# Altium schematic sheet POWER.SchDoc(POWER)
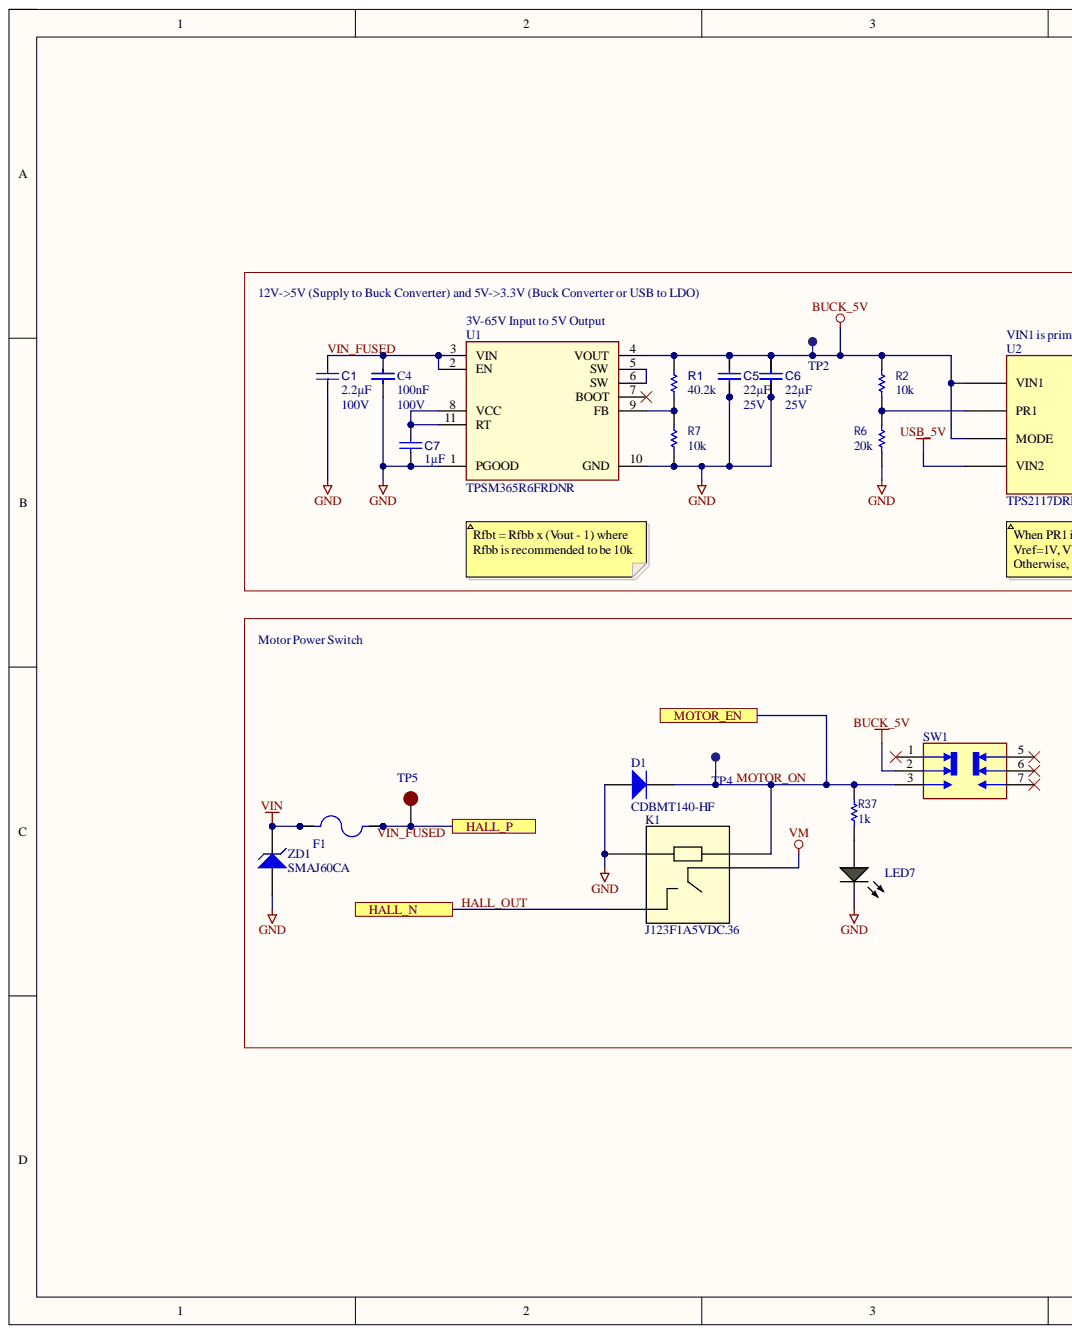
[diagram p0: POWER.SchDoc, left]
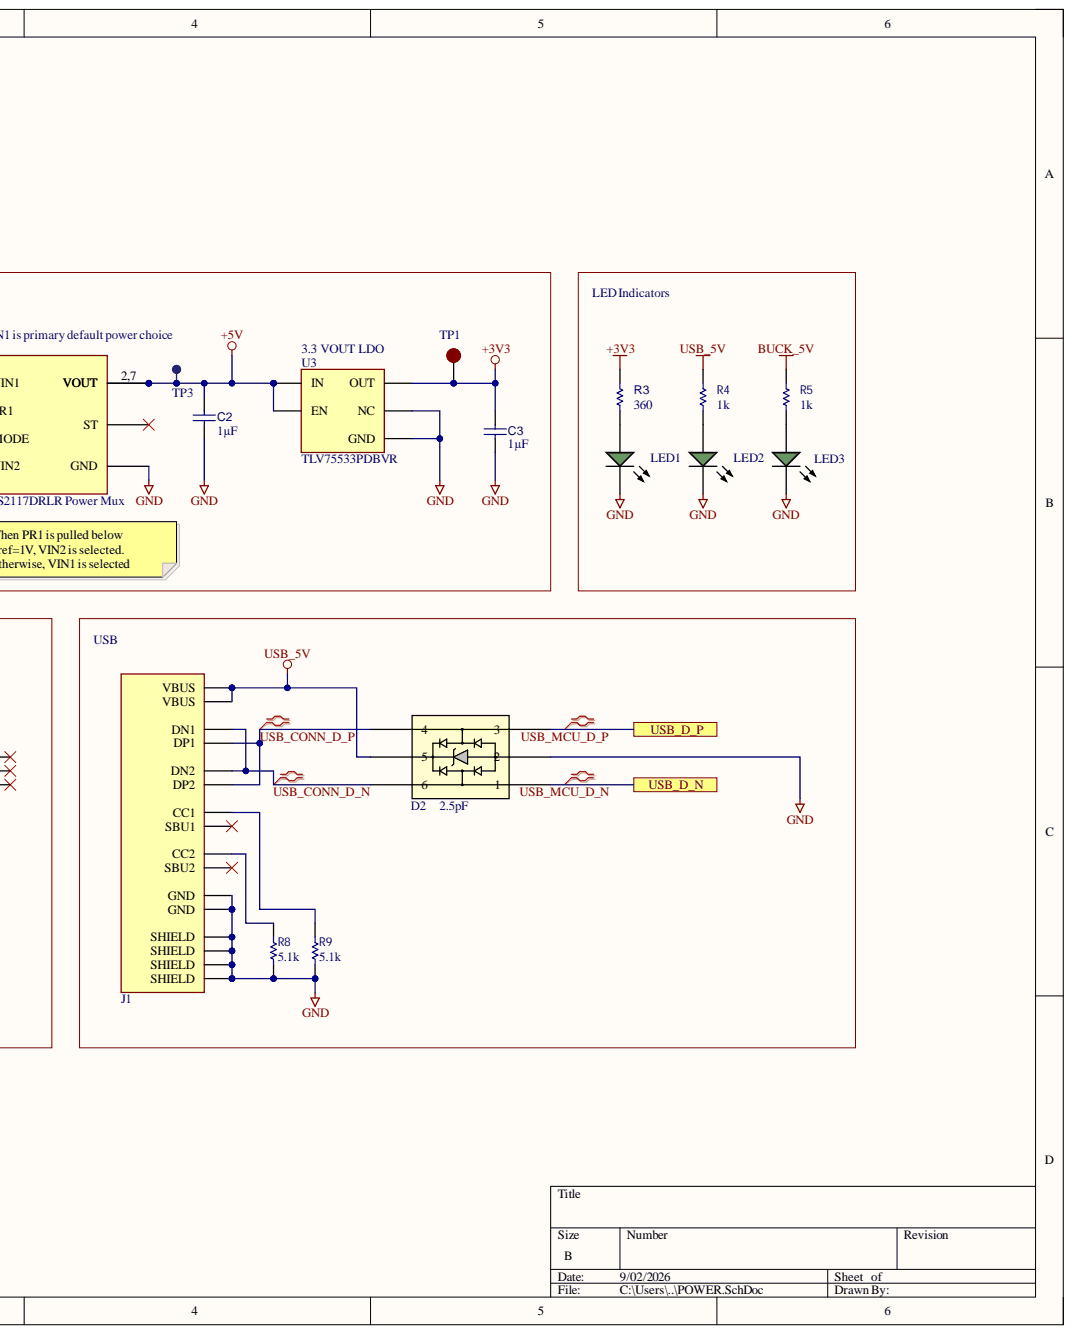
[diagram p1: POWER.SchDoc, right]

## Parts
C1  2.2µF  Voltage Rating: 100V  [CAPC3216X200N]
C2  1µF  [0603_Capacitor]
C3  1µF  [0603_Capacitor]
C4  100nF  Voltage Rating: 100V  [0603_Capacitor]
C5  22µF  Voltage Rating: 25V  [CAPC3225X28N]
C6  22µF  Voltage Rating: 25V  [CAPC3225X28N]
C7  1µF  [0603_Capacitor]
D1  CDBMT140-HF  [FP-SOD123F_Type_B-MFG]
D2  2.5pF  [SOT23-6L_STM]
F1  3557-2  [FUSE_3557-2]  (value not drawn)
J1  UJ20-C-H-G-SMT-1-P16-TR  [SAMESKY_UJ20-C-H-G-SMT-1-P16-TR]  (value not drawn)
K1  J123F1A5VDC.36  [RELAY4_J123F-1A_CRS]
LED1  LTST-C190GKT  [LTST-C190GKT_V]  (value not drawn)
LED2  LTST-C190GKT  [LTST-C190GKT_V]  (value not drawn)
LED3  LTST-C190GKT  [LTST-C190GKT_V]  (value not drawn)
LED7  LTST-C190GKT  [LTST-C190GKT_V]  (value not drawn)
R1  40.2k  [R-0402]
R2  value 10k  [R-0402]  (hidden comment: Resistor 10k)
R3  360  [R-0402]
R4  value 1k  [R-0402]  (hidden comment: Resistor 1k)
R5  value 1k  [R-0402]  (hidden comment: Resistor 1k)
R6  value 20k  [R-0402]  (hidden comment: Resistor 20k)
R7  value 10k  [R-0402]  (hidden comment: Resistor 10k)
R8  value 5.1k  [R-0402]  (hidden comment: Resistor 5.1k)
R9  value 5.1k  [R-0402]  (hidden comment: Resistor 5.1k)
R37  value 1k  [R-0402]  (hidden comment: Resistor 1k)
SW1  JS202011JCQN  [SW_JS202011JCQN]  (value not drawn)
TP1  5000 TP  [FP-5000-MFG]  (value not drawn)
TP2  Test Pad  [Test_Pad]  (value not drawn)  (no BOM)
TP3  Test Pad  [Test_Pad]  (value not drawn)  (no BOM)
TP4  Test Pad  [Test_Pad]  (value not drawn)  (no BOM)
TP5  5000 TP  [FP-5000-MFG]  (value not drawn)
U1  TPSM365R6FRDNR  [RDN0011A-MFG_FIXED]
U2  TPS2117DRLR Power Mux  Manufacturer PN: TPS2117DRLR  Type: Power Mux  [SOTFL50P160X60-8N]
U3  TLV75533PDBVR  Type: LDO  [FP-DBV0005A-IPC_C]
ZD1  SMAJ60CA  [SMASeries_LTF]

## Ports
HALL_N, HALL_P, MOTOR_EN, USB_D_N, USB_D_P

## Nets
+3V3: C3.1 R3.2 TP1.1 U3.5(OUT)
+5V: C2.1 TP3.TP? U2.2(VOUT) U2.7 U3.1(IN) U3.3(EN)
BUCK_5V: C5.2 C6.2 R1.2 R2.2 R5.2 SW1.2 TP2.TP? U1.4(VOUT) U2.3(VIN1) U2.5(MODE)
GND: C1.1 C2.2 C3.2 C4.2 C5.1 C6.1 C7.1 D1.1 D2.2 J1.A1_B12(GND) J1.B1_A12(GND) J1.SH1(SHIELD) J1.SH2(SHIELD) J1.SH3(SHIELD) J1.SH4(SHIELD) K1.2 LED1.2 LED2.2 LED3.2 LED7.2 R6.1 R7.1 R8.1 R9.1 U1.1(PGOOD) U1.10(GND) U2.1(GND) U3.2(GND) U3.4(NC) ZD1.1
USB_5V: D2.5 J1.A4_B9(VBUS) J1.B4_A9(VBUS) R4.2 U2.6(VIN2)
VIN: F1.1_1 F1.1_2 ZD1.2
VM: K1.1
HALL_OUT: K1.3 ; port HALL_N
MOTOR_ON: D1.2 K1.4 R37.2 SW1.3 TP4.TP? ; port MOTOR_EN
USB_CONN_D_N: D2.6 J1.A7(DN1) J1.B7(DN2)
USB_CONN_D_P: D2.4 J1.A6(DP1) J1.B6(DP2)
USB_MCU_D_N: D2.1 ; port USB_D_N
USB_MCU_D_P: D2.3 ; port USB_D_P
VIN_FUSED: C1.2 C4.1 F1.2_1 F1.2_2 TP5.1 U1.2(EN) U1.3(VIN) ; port HALL_P
NetC7_2: C7.2 U1.8(VCC) U1.11(RT)
NetJ1_A5: J1.A5(CC1) R9.2
NetJ1_B5: J1.B5(CC2) R8.2
NetLED1_1: LED1.1 R3.1
NetLED2_1: LED2.1 R4.1
NetLED3_1: LED3.1 R5.1
NetLED7_1: LED7.1 R37.1
NetR1_1: R1.1 R7.2 U1.9(FB)
NetR2_1: R2.1 R6.2 U2.4(PR1)
NetU1_5: U1.5(SW) U1.6(SW)

Not connected: J1 A8(SBU1) B8(SBU2); SW1 1 5 6 7; U1 7(BOOT); U2 12(ST)

## Library data of the parts
C1 = CMP-001-00240-1: Cap Ceramic 2.2uF 100V X7R 10% Pad SMD 1206 125C T/R Automotive AEC-Q200; Manufacturer: KYOCERA AVX; Manufacturer PN: 12061C225K4T2A; Type: Capacitor X7R; Component Kind: Standard; ComponentLink1Description: Datasheet; ComponentLink1URL: https://datasheets.kyocera-avx.com/AutoMLCC.pdf; Library Name: BLDC_Symbols.SchLib; Package: 1206; Supplier 1: Digikey; Supplier Part Number 1: 478-KAM31GR72A225KUCT-ND; Tolerance: 10%; Value: 2.2µF
C2 C3 C7 = CMP-001-00209-4: 1 µF ±10% 25V Ceramic Capacitor X7R 0603 (1608 Metric); Manufacturer: Samsung Electro-Mechanics; Manufacturer PN: CL10B105KA8NNNC; Component Kind: Standard; ComponentLink1Description: Datasheet; ComponentLink1URL: https://mm.digikey.com/Volume0/opasdata/d220001/medias/docus/3644/CL10B105KA8NNNC_spec.pdf; Library Name: BLDC_Symbols.SchLib; Package: 0603; Supplier 1: Digikey; Supplier Part Number 1: 1276-1184-1-ND; Tolerance: 10%; Type: Capacitor X7R; Value: 1µF; Voltage Rating: 25V
C4 = CAP, SMD, 0.1uF 0603 100V X7R: 0.1 µF ±10% 100V Ceramic Capacitor X7R 0603 (1608 Metric); Manufacturer: Walsin Technology Corporation; Manufacturer PN: 0603B104K101CT; Component Kind: Standard; ComponentLink1Description: Datasheet; ComponentLink1URL: https://www.mouser.com/datasheet/3/508/1/KEM_C1002_X7R_SMD.pdf; Library Name: BLDC_Symbols.SCHLIB; Package: 0603; Supplier 1: Digikey; Supplier Part Number 1: 1292-1392-1-ND; Tolerance: 10%; Type: Capacitor X7R; Value: 100nF
C5 C6 = CMP-001-00218-1: 25V 22uF X7R 卤20% 1210 Multilayer Ceramic Capacitors MLCC - SMD/SMT ROHS; Manufacturer: TDK; Manufacturer PN: C3225X7R1E226M250AB; Tolerance: 20%; Type: Capacitor X7R; Component Kind: Standard; ComponentLink1Description: Datasheet; ComponentLink1URL: https://product.tdk.com/system/files/dam/doc/product/capacitor/ceramic/mlcc/catalog/mlcc_commercial_general_en.pdf; Library Name: BLDC_Symbols.SchLib; Package: 1210; Supplier 1: Digikey; Supplier Part Number 1: 445-C3225X7R1E226M250ABCT-ND; Value: 22µF
D1 = CMP-00027-2: Rectifier Diode, 1 Phase, 1 Element, 1A, 40V V(RRM), Silicon; Manufacturer: Comchip; Type: Schottky Diode; Component Kind: Standard; ComponentLink1Description: Datasheet; ComponentLink1URL: https://www.comchiptech.com/admin/files/product/CDBMT140-HF%20Thru%20CDBMT1200-HF%20RevC.pdf; Current: 1A; Library Name: BLDC_Symbols.SchLib; Manufacturer PN: CDBMT140-HF; Package: SOD123H; Supplier 1: Digikey; Supplier Part Number 1: 641-1443-1-ND; Value: CDBMT140-HF; Voltage Rating: 40V
D2 = CMP-004-00065-3: 5A 17V 6V Unidirectional 5.25V SOT-23-6 ESD and Surge Protection (TVS/ESD) ROHS; Manufacturer: STMicroelectronics; Manufacturer PN: USBLC6-2SC6; Type: TVS Diode Array; Component Kind: Standard; ComponentLink1Description: Datasheet; ComponentLink1URL: https://www.st.com/resource/en/datasheet/cd00050750.pdf; Current: 5A; Library Name: BLDC_Symbols.SchLib; Package: SOT-23; Supplier 1: Mouser; Value: 2.5pF; Voltage Rating: 17V
F1 = CMP-005-00018-1: THM 2 in 1 Auto Blade Holder; Manufacturer: Keystone Electronics; Current: 30A; Type: Fuse Holder; Voltage Rating: 500V; Component Kind: Standard; ComponentLink1Description: Datasheet; ComponentLink1URL: https://www.keyelco.com/userAssets/file/K75p47.pdf; Library Name: BLDC_Symbols.SchLib; Manufacturer PN: 3557-2; Package: TH; Supplier 1: Digikey; Supplier Part Number 1: 36-3557-2-ND; Value: 3557-2
J1 = CMP-002-00331-2: USB-C (USB TYPE-C) USB 2.0 Receptacle Connector 24 (16+8 Dummy) Position Surface Mount, Right Angle; Through Hole; Manufacturer: Same Sky (Formerly CUI Devices); Voltage Rating: 48V; Component Kind: Standard; ComponentLink1Description: Datasheet; ComponentLink1URL: https://www.sameskydevices.com/product/resource/uj20-c-h-g-smt-1-p16-tr.pdf; Library Name: BLDC_Symbols.SchLib; Manufacturer PN: UJ20-C-H-G-SMT-1-P16-TR; Package: SMD; Supplier 1: Digikey; Supplier Part Number 1: 2223-UJ20-C-H-G-SMT-1-P16-TRCT-ND; Type: USB-C Connector (Right Angle); Value: UJ20-C-H-G-SMT-1-P16-TR
K1 = CMP-008-00026-1: Description; Manufacturer: CIT Relay and Switch; Current: 20A; Power: 2200W; Voltage Rating: 110V; Component Kind: Standard; ComponentLink1Description: Datasheet; ComponentLink1URL: https://www.citrelay.com/wp-content/uploads/2025/05/Relay-J123F.pdf; Library Name: BLDC_Symbols.SchLib; Manufacturer PN: J123F1A5VDC.36; Package: TH; Supplier 1: Digikey; Supplier Part Number 1: 2449-J123F1A5VDC.36-ND; Type: Power Relays; Value: J123F1A5VDC.36
LED1-LED3 LED7 = CMP-007-00022-2: LED Uni-Color Green, 100 mW, 30 mA, -55 to 85 degC, 2-Pin SMD, RoHS, Tape and Reel; Manufacturer: Lite-On Inc.; Current: 30mA; Power: 100mW; Component Kind: Standard; ComponentLink1Description: Datasheet; ComponentLink1URL: https://mm.digikey.com/Volume0/opasdata/d220001/medias/docus/858/LTST-C190GKT.pdf; Library Name: BLDC_Symbols.SchLib; Manufacturer PN: LTST-C190GKT; Package: 0603; Supplier 1: Digikey; Supplier Part Number 1: 160-LTST-C190GKTCT-ND; Type: LED; Value: LTST-C190GKT
R1 = RES, SMD, 40.2K 0402: 40.2 kOhms ±0.1% 0.063W, 1/16W Chip Resistor 0402 (1005 Metric) Automotive AEC-Q200 Thin Film; Manufacturer: Panasonic Industry; Manufacturer PN: ERA-2AEB4022X; Power: 0.1W; Component Kind: Standard; ComponentLink1Description: Datasheet; Library Name: BLDC_Symbols.SchLib; Package: 0402; Supplier 1: Digikey; Supplier Part Number 1: P40.2KDCCT-ND; Tolerance: 0.1%; Type: Resistor; Value: 40.2k
R2 R7 = Resistor 10k: 10 kOhms ±5% 0.063W, 1/16W Chip Resistor 0402 (1005 Metric) Moisture Resistant Thick Film; Manufacturer: YAGEO; Manufacturer PN: RC0402JR-0710KL; Power: 0.0625; Component Kind: Standard; ComponentLink1Description: Datasheet; Library Name: BLDC_Symbols.SchLib; Package: 0402; Supplier 1: Digikey; Supplier Part Number 1: 311-10KJRCT-ND; Tolerance: 5%; Type: Resistor
R3 = RES, 360 OHM 1% 1/16W 0402: 360 Ohms ±1% 0.063W, 1/16W Chip Resistor 0402 (1005 Metric) Moisture Resistant Thick Film; Manufacturer: YAGEO; Manufacturer PN: RC0402FR-13360RL; Power: 0.1W; Component Kind: Standard; ComponentLink1Description: Datasheet; Library Name: BLDC_Symbols.SCHLIB; Package: 0402; Supplier 1: Digikey; Supplier Part Number 1: 13-RC0402FR-13360RLCT-ND; Tolerance: 1%; Type: Resistor; Value: 360
R4 R5 R37 = Resistor 1k: 1 kOhms ±1% 0.063W, 1/16W Chip Resistor 0402 (1005 Metric) Moisture Resistant Thick Film; Manufacturer: YAGEO; Manufacturer PN: RC0402FR-071KL; Power: 0.0625; Component Kind: Standard; ComponentLink1Description: Datasheet; Library Name: BLDC_Symbols.SchLib; Package: 0402; Supplier 1: Digikey; Supplier Part Number 1: 311-1.00KLRCT-ND; Tolerance: 1%; Type: Resistor
R6 = Resistor 20k: 20 kOhms ±1% 0.063W, 1/16W Chip Resistor 0402 (1005 Metric) Moisture Resistant Thick Film; Manufacturer: YAGEO; Manufacturer PN: RC0402FR-0720KL; Power: 0.0625; Component Kind: Standard; ComponentLink1Description: Datasheet; Library Name: BLDC_Symbols.SchLib; Package: 0402; Supplier 1: Digikey; Supplier Part Number 1: 311-20.0KLRCT-ND; Tolerance: 1%; Type: Resistor
R8 R9 = Resistor 5.1k: 5.1 kOhms ±1% 0.063W, 1/16W Chip Resistor 0402 (1005 Metric) Moisture Resistant Thick Film; Manufacturer: YAGEO; Manufacturer PN: RC0402FR-075K1L; Power: 0.0625; Component Kind: Standard; ComponentLink1Description: Datasheet; Library Name: BLDC_Symbols.SchLib; Package: 0402; Supplier 1: Digikey; Supplier Part Number 1: 311-5.10KLRCT-ND; Tolerance: 1%; Type: Resistor
SW1 = CMP-011-00052-2: Slide Switch DPDT Surface Mount; Manufacturer: C&K; Current: 300mA; Voltage Rating: 6V; Component Kind: Standard; ComponentLink1Description: Datasheet; Library Name: BLDC_Symbols.SchLib; Manufacturer PN: JS202011JCQN; Package: SMD; Supplier 1: Digikey; Supplier Part Number 1: CKN10723CT-ND; Type: Switch; Value: JS202011JCQN
TP1 TP5 = CMP-00030-00018-2: Keystone 5000 Test Point ultra compact; Manufacturer PN: 5000; Component Kind: Standard; ComponentLink1Description: Datasheet; ComponentLink1URL: https://www.keyelco.com/userAssets/file/K75p62.pdf; Library Name: BLDC_Symbols.SchLib; Manufacturer: Keystone; Package: TH; Supplier 1: Digikey; Supplier Part Number 1: 36-5000-ND; Type: Test Point; Value: 5000 TP
TP2-TP4 = CMP-00030-00017-2: Small Test Pad for Testing - Natsu; ComponentLink1Description: Datasheet; Library Name: BLDC_Symbols.SCHLIB; Type: Test Pad; Value: Test Pad
U1 = IC, BUCK 0.6ATPSM365R6FRDNR: Non-Isolated PoL Module DC DC Converter 1 Output 1 ~ 13V 600mA 3.6V - 65V Input; Manufacturer: Texas Instruments; Tolerance: QFN; Component Kind: Standard; ComponentLink1Description: Datasheet; ComponentLink1URL: https://www.ti.com/general/docs/suppproductinfo.tsp?distId=10&gotoUrl=https%3A%2F%2Fwww.ti.com%2Flit%2Fgpn%2Flm5166; Current: 600mA; Library Name: BLDC_Symbols.SCHLIB; Manufacturer PN: TPSM365R6FRDNR; Package: DFN; Supplier 1: Digikey; Supplier Part Number 1: 296-TPSM365R6FRDNRCT-ND; Type: Buck; Value: TPSM365R6FRDNR; Voltage Rating: 65V
U2 = CMP-031-00038-1: TPS2117 1.6-V to 5.5-V, 4-A Low IQ Power Mux With Manual and Priority Switchover; Manufacturer: Texas Instruments; Current: 4A; Voltage Rating: 5.5V; Component Kind: Standard; ComponentLink1Description: Datasheet; ComponentLink1URL: https://www.ti.com/lit/ds/symlink/tps2117.pdf?ts=1701351126648&ref_url=https%253A%252F%252Fwww.google.com%252F; Library Name: BLDC_Symbols.SchLib; Package: SOT; Supplier 1: Digikey; Supplier Part Number 1: 296-TPS2117DRLRCT-ND; Value: TPS2117DRLR Power Mux
U3 = CMP-00053-1: 500mA high-PSRR low-IQ low-dropout voltage regulator with enable 5-SOT-23 -40 to 125; Manufacturer: Texas Instruments; Voltage Rating: 5.5V; Component Kind: Standard; ComponentLink1Description: Datasheet; ComponentLink1URL: https://www.ti.com/lit/ds/symlink/tlv755p.pdf?HQS=dis-dk-null-digikeymode-dsf-pf-null-wwe&ts=1781033296492&ref_url=https%253A%252F%252Fwww.ti.com%252Fgeneral%252Fdocs%252Fsuppproductinfo.tsp%253FdistId%253D10%2526gotoUrl%253Dhttps%253A%252F%252Fwww.ti.com%252Flit%252Fgpn%252Ftlv755p; Current: 500mA; Library Name: BLDC_Symbols.SchLib; Manufacturer PN: TLV75533PDBVR; Package: SOT; Supplier 1: Digikey; Supplier Part Number 1: 296-50411-1-ND; Value: TLV75533PDBVR
ZD1 = CMP-835-000000-3: Description; Manufacturer: Littelfuse; Current: 4.1A; Power: 600W; Voltage Rating: 96.8V; Component Kind: Standard; ComponentLink1Description: Datasheet; ComponentLink1URL: https://www.littelfuse.com/assetdocs/tvs-diodes-smaj-datasheet?assetguid=13c2a823-03b8-4d1f-9ddc-9b44670aed9d; Library Name: BLDC_Symbols.SchLib; Manufacturer PN: SMAJ60CA; Package: SMA; Supplier 1: Digikey; Supplier Part Number 1: SMAJ60CALFCT-ND; Type: TVS Diode; Value: SMAJ60CA
